# Altium schematic sheet [5]-BeagleBlack Connectors.SchDoc
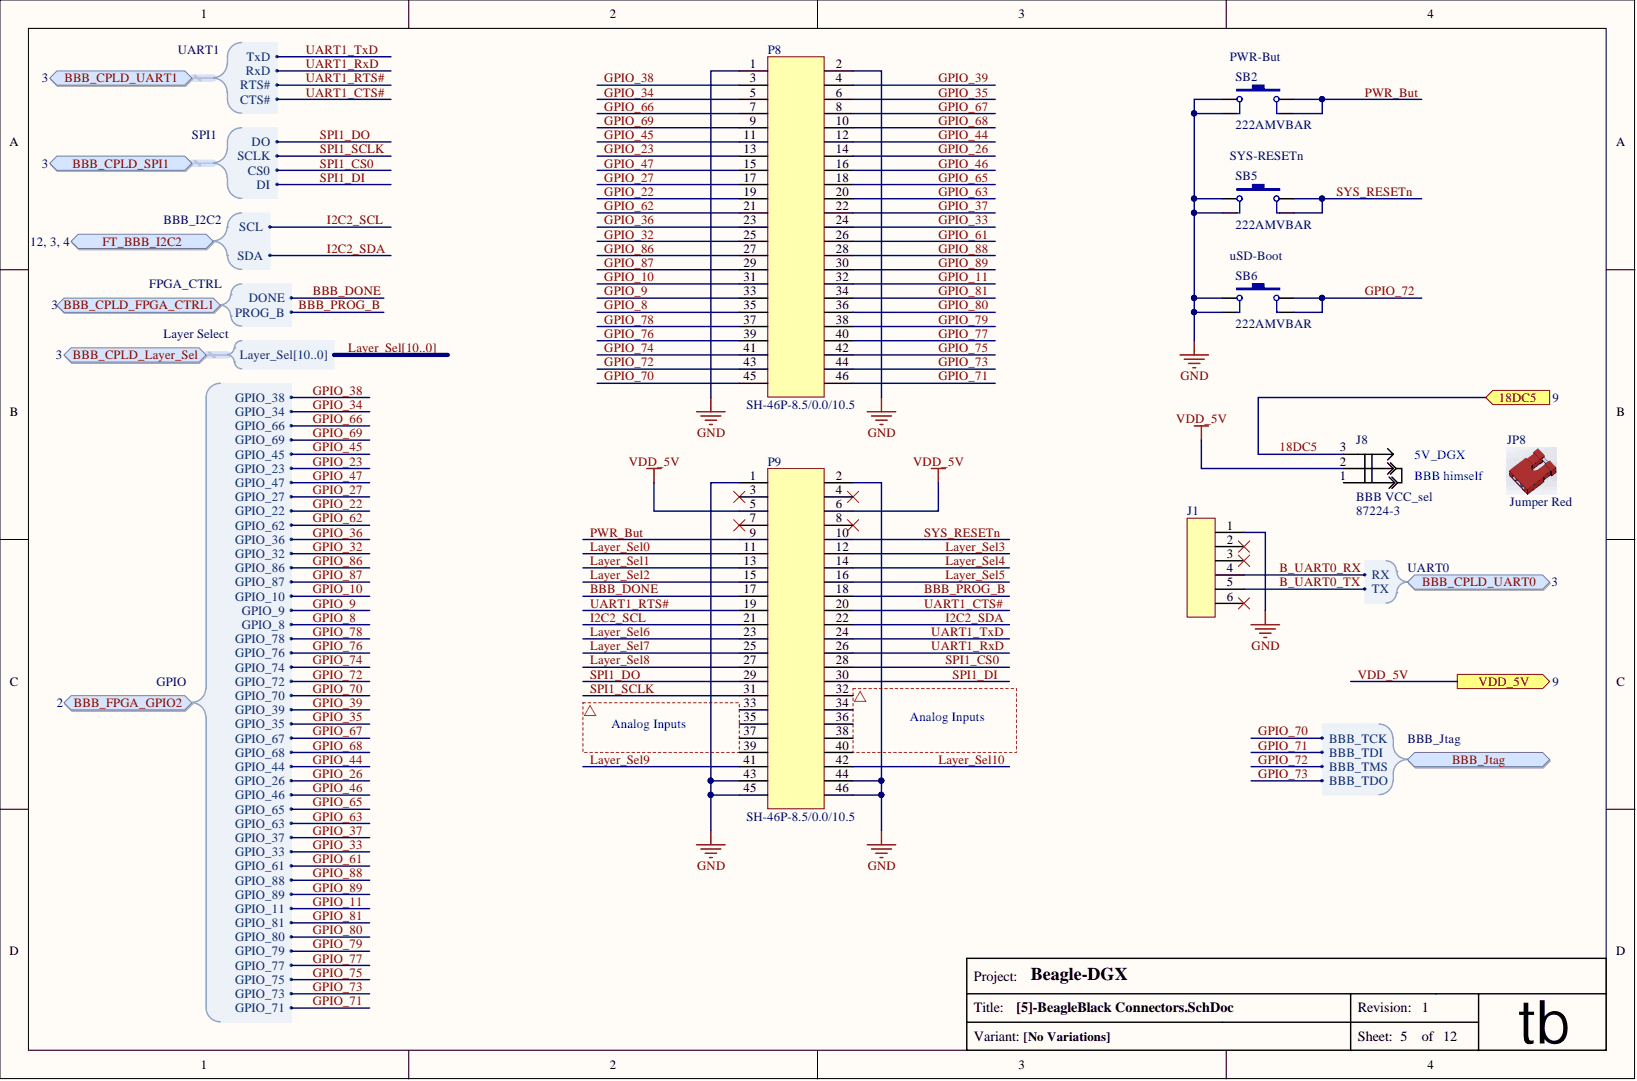
[diagram p0: [5]-BeagleBlack Connectors.SchDoc]

## Parts
J1  conn_Arduino_6p  [Conn_Arduino_6p_2.54]  (value not drawn)
J8  BBB VCC_sel  value 87224-3  Manufacturer Part: 87224-3  [Jumper_3pin_red]
JP8  value Jumper Red  Supplier Part: Jumper  (hidden comment: VCC_sel)  (mechanical)
P8  value SH-46P-8.5/0.0/10.5  Manufacturer Part: SH-46P-8.5/0.0/10.5  [Conn_Beagle_2x23p_2.54]  (hidden comment: conn_Beagle_2x23p)
P9  value SH-46P-8.5/0.0/10.5  Manufacturer Part: SH-46P-8.5/0.0/10.5  [Conn_Beagle_2x23p_2.54]  (hidden comment: conn_Beagle_2x23p)
SB2  PWR-But  value 222AMVBAR  Manufacturer Part: 222AMVBAR  [Key 6.2x6.2]
SB5  SYS-RESETn  value 222AMVBAR  Manufacturer Part: 222AMVBAR  [Key 6.2x6.2]
SB6  uSD-Boot  value 222AMVBAR  Manufacturer Part: 222AMVBAR  [Key 6.2x6.2]

## Ports
18DC5, BBB_CPLD_FPGA_CTRL1, BBB_CPLD_FPGA_CTRL1.DONE, BBB_CPLD_FPGA_CTRL1.PROG_B, BBB_CPLD_Layer_Sel, BBB_CPLD_LAYER_SEL.LAYER_SEL0, BBB_CPLD_LAYER_SEL.LAYER_SEL1, BBB_CPLD_LAYER_SEL.LAYER_SEL2, BBB_CPLD_LAYER_SEL.LAYER_SEL3, BBB_CPLD_LAYER_SEL.LAYER_SEL4, BBB_CPLD_LAYER_SEL.LAYER_SEL5, BBB_CPLD_LAYER_SEL.LAYER_SEL6, BBB_CPLD_LAYER_SEL.LAYER_SEL7, BBB_CPLD_LAYER_SEL.LAYER_SEL8, BBB_CPLD_LAYER_SEL.LAYER_SEL9, BBB_CPLD_LAYER_SEL.LAYER_SEL10, BBB_CPLD_Layer_Sel.Layer_Sel[10..0], BBB_CPLD_SPI1, BBB_CPLD_SPI1.CS0, BBB_CPLD_SPI1.DI, BBB_CPLD_SPI1.DO, BBB_CPLD_SPI1.SCLK, BBB_CPLD_UART0, BBB_CPLD_UART0.RX, BBB_CPLD_UART0.TX, BBB_CPLD_UART1, BBB_CPLD_UART1.CTS#, BBB_CPLD_UART1.RTS#, BBB_CPLD_UART1.RxD, BBB_CPLD_UART1.TxD, BBB_FPGA_GPIO2, BBB_FPGA_GPIO2.GPIO_8, BBB_FPGA_GPIO2.GPIO_9, BBB_FPGA_GPIO2.GPIO_10, BBB_FPGA_GPIO2.GPIO_11, BBB_FPGA_GPIO2.GPIO_22, BBB_FPGA_GPIO2.GPIO_23, BBB_FPGA_GPIO2.GPIO_26, BBB_FPGA_GPIO2.GPIO_27, BBB_FPGA_GPIO2.GPIO_32, BBB_FPGA_GPIO2.GPIO_33, BBB_FPGA_GPIO2.GPIO_34, BBB_FPGA_GPIO2.GPIO_35, BBB_FPGA_GPIO2.GPIO_36, BBB_FPGA_GPIO2.GPIO_37, BBB_FPGA_GPIO2.GPIO_38, BBB_FPGA_GPIO2.GPIO_39, BBB_FPGA_GPIO2.GPIO_44, BBB_FPGA_GPIO2.GPIO_45, BBB_FPGA_GPIO2.GPIO_46, BBB_FPGA_GPIO2.GPIO_47, BBB_FPGA_GPIO2.GPIO_61, BBB_FPGA_GPIO2.GPIO_62, BBB_FPGA_GPIO2.GPIO_63, BBB_FPGA_GPIO2.GPIO_65, BBB_FPGA_GPIO2.GPIO_66, BBB_FPGA_GPIO2.GPIO_67, BBB_FPGA_GPIO2.GPIO_68, BBB_FPGA_GPIO2.GPIO_69, BBB_FPGA_GPIO2.GPIO_70, BBB_FPGA_GPIO2.GPIO_71, BBB_FPGA_GPIO2.GPIO_72, BBB_FPGA_GPIO2.GPIO_73, BBB_FPGA_GPIO2.GPIO_74, BBB_FPGA_GPIO2.GPIO_75, BBB_FPGA_GPIO2.GPIO_76, BBB_FPGA_GPIO2.GPIO_77, BBB_FPGA_GPIO2.GPIO_78, BBB_FPGA_GPIO2.GPIO_79, BBB_FPGA_GPIO2.GPIO_80, BBB_FPGA_GPIO2.GPIO_81, BBB_FPGA_GPIO2.GPIO_86, BBB_FPGA_GPIO2.GPIO_87, BBB_FPGA_GPIO2.GPIO_88, BBB_FPGA_GPIO2.GPIO_89, BBB_Jtag, BBB_Jtag.BBB_TCK, BBB_Jtag.BBB_TDI, BBB_Jtag.BBB_TDO, BBB_Jtag.BBB_TMS, FT_BBB_I2C2, FT_BBB_I2C2.SCL, FT_BBB_I2C2.SDA, VDD_5V

## Nets
GND: J1.1 P8.1 P8.2 P9.1 P9.2 P9.43 P9.44 P9.45 P9.46 SB2.1 SB2.3 SB5.1 SB5.3 SB6.1 SB6.3
18DC5: J8.3 ; port 18DC5
B_UART0_RX: J1.4 ; port BBB_CPLD_UART0
B_UART0_TX: J1.5 ; port BBB_CPLD_UART0
BBB_DONE: P9.17 ; port BBB_CPLD_FPGA_CTRL1
BBB_PROG_B: P9.18 ; port BBB_CPLD_FPGA_CTRL1
GPIO_8: P8.35 ; port BBB_FPGA_GPIO2
GPIO_9: P8.33 ; port BBB_FPGA_GPIO2
GPIO_10: P8.31 ; port BBB_FPGA_GPIO2
GPIO_11: P8.32 ; port BBB_FPGA_GPIO2
GPIO_22: P8.19 ; port BBB_FPGA_GPIO2
GPIO_23: P8.13 ; port BBB_FPGA_GPIO2
GPIO_26: P8.14 ; port BBB_FPGA_GPIO2
GPIO_27: P8.17 ; port BBB_FPGA_GPIO2
GPIO_32: P8.25 ; port BBB_FPGA_GPIO2
GPIO_33: P8.24 ; port BBB_FPGA_GPIO2
GPIO_34: P8.5 ; port BBB_FPGA_GPIO2
GPIO_35: P8.6 ; port BBB_FPGA_GPIO2
GPIO_36: P8.23 ; port BBB_FPGA_GPIO2
GPIO_37: P8.22 ; port BBB_FPGA_GPIO2
GPIO_38: P8.3 ; port BBB_FPGA_GPIO2
GPIO_39: P8.4 ; port BBB_FPGA_GPIO2
GPIO_44: P8.12 ; port BBB_FPGA_GPIO2
GPIO_45: P8.11 ; port BBB_FPGA_GPIO2
GPIO_46: P8.16 ; port BBB_FPGA_GPIO2
GPIO_47: P8.15 ; port BBB_FPGA_GPIO2
GPIO_61: P8.26 ; port BBB_FPGA_GPIO2
GPIO_62: P8.21 ; port BBB_FPGA_GPIO2
GPIO_63: P8.20 ; port BBB_FPGA_GPIO2
GPIO_65: P8.18 ; port BBB_FPGA_GPIO2
GPIO_66: P8.7 ; port BBB_FPGA_GPIO2
GPIO_67: P8.8 ; port BBB_FPGA_GPIO2
GPIO_68: P8.10 ; port BBB_FPGA_GPIO2
GPIO_69: P8.9 ; port BBB_FPGA_GPIO2
GPIO_70: P8.45 ; port BBB_FPGA_GPIO2, port BBB_Jtag
GPIO_71: P8.46 ; port BBB_FPGA_GPIO2, port BBB_Jtag
GPIO_72: P8.43 SB6.2 SB6.4 ; port BBB_FPGA_GPIO2, port BBB_Jtag
GPIO_73: P8.44 ; port BBB_FPGA_GPIO2, port BBB_Jtag
GPIO_74: P8.41 ; port BBB_FPGA_GPIO2
GPIO_75: P8.42 ; port BBB_FPGA_GPIO2
GPIO_76: P8.39 ; port BBB_FPGA_GPIO2
GPIO_77: P8.40 ; port BBB_FPGA_GPIO2
GPIO_78: P8.37 ; port BBB_FPGA_GPIO2
GPIO_79: P8.38 ; port BBB_FPGA_GPIO2
GPIO_80: P8.36 ; port BBB_FPGA_GPIO2
GPIO_81: P8.34 ; port BBB_FPGA_GPIO2
GPIO_86: P8.27 ; port BBB_FPGA_GPIO2
GPIO_87: P8.29 ; port BBB_FPGA_GPIO2
GPIO_88: P8.28 ; port BBB_FPGA_GPIO2
GPIO_89: P8.30 ; port BBB_FPGA_GPIO2
I2C2_SCL: P9.21 ; port FT_BBB_I2C2
I2C2_SDA: P9.22 ; port FT_BBB_I2C2
Layer_Sel0: P9.11 ; port BBB_CPLD_Layer_Sel  [also labelled LAYER_SEL]
Layer_Sel1: P9.13 ; port BBB_CPLD_Layer_Sel  [also labelled LAYER_SEL]
Layer_Sel2: P9.15 ; port BBB_CPLD_Layer_Sel  [also labelled LAYER_SEL]
Layer_Sel3: P9.12 ; port BBB_CPLD_Layer_Sel  [also labelled LAYER_SEL]
Layer_Sel4: P9.14 ; port BBB_CPLD_Layer_Sel  [also labelled LAYER_SEL]
Layer_Sel5: P9.16 ; port BBB_CPLD_Layer_Sel  [also labelled LAYER_SEL]
Layer_Sel6: P9.23 ; port BBB_CPLD_Layer_Sel  [also labelled LAYER_SEL]
Layer_Sel7: P9.25 ; port BBB_CPLD_Layer_Sel  [also labelled LAYER_SEL]
Layer_Sel8: P9.27 ; port BBB_CPLD_Layer_Sel  [also labelled LAYER_SEL]
Layer_Sel9: P9.41 ; port BBB_CPLD_Layer_Sel  [also labelled LAYER_SEL]
Layer_Sel10: P9.42 ; port BBB_CPLD_Layer_Sel  [also labelled LAYER_SEL]
PWR_But: P9.9 SB2.2 SB2.4
SPI1_CS0: P9.28 ; port BBB_CPLD_SPI1
SPI1_DI: P9.30 ; port BBB_CPLD_SPI1
SPI1_DO: P9.29 ; port BBB_CPLD_SPI1
SPI1_SCLK: P9.31 ; port BBB_CPLD_SPI1
SYS_RESETn: P9.10 SB5.2 SB5.4
UART1_CTS#: P9.20 ; port BBB_CPLD_UART1
UART1_RTS#: P9.19 ; port BBB_CPLD_UART1
UART1_RxD: P9.26 ; port BBB_CPLD_UART1
UART1_TxD: P9.24 ; port BBB_CPLD_UART1
VDD_5V: J8.2 P9.5 P9.6 ; port VDD_5V

Not connected: J1 2 3 6; J8 1; P9 3 4 7 8 32 33 34 35 36 37 38 39 40

## Library data of the parts
J1 = conn_Arduino_6p: PLS-6 2.54mm Pitch, 10.5mm High; Manufacturer Part: Header 1x6; Type of Component: Header; Component Kind: Standard; Package / Case: Header 1x6; Supplier: aliexpress.com; Supplier Link: http://aliexpress.com/item/40PCS-x-8-Pin-40x-6-Pin-Stackable-Female-Shield-Header-Tall-Stacking-Kit-Special-long/689203895.html; Library Name: RPI-VITA.IntLib
J8 = jumper_3pin: Manufacturer: TE Connectivity / AMP; Type of Component: Jumper; Component Kind: Standard; Manufacturer Link: http://www.te.com/usa-en/product-87224-3.html#pdp-related-products-inner; Package / Case: Header 1 x 3; Supplier: mouser; Supplier Link: http://www.mouser.ee/ProductDetail/TE-Connectivity-AMP/87224-3/?qs=sGAEpiMZZMs%252bGHln7q6pm48SVpWlpfsErguO%2fp0873w%3d; Supplier Part: 571-872243; Library Name: RPI-VITA.IntLib
JP8 = jumper red: Manufacturer: Great Electric; Manufacturer Part: Jumper Cap Shunts Short Circuit Cap; Package / Case: 2.54mm; Supplier: aliexpress.com; Supplier Link: http://www.aliexpress.com/item/500pcs-lot-Asssorted-Color-2-54mm-Standard-Circuit-Board-Jumper-Cap-Shunts-Short-Circuit-Cap-CGKCH119/32344305619.html?spm=2114.03010208.3.80.nPPmoy&ws_ab_test=searchweb201556_1,searchweb201644_1_505_506_503_504_502_10001_10002_10017_10010_10005_10011_10006_10003_10004_10009_10007_10008,searchweb201560_5,searchweb1451318400_-1,searchweb1451318411_6452&btsid=16d11777-edde-4755-b8b5-9adacb495b09; Type of Component: Jumper; Library Name: RPI-VITA.IntLib
P8 P9 = conn_Beagle_2x23p: Arduino 2x23 2.54mm Pitch; Manufacturer: Hellotronics; Type of Component: Header 2x23; Component Kind: Standard; Package / Case: Header 2x23; Supplier: aliexpress.com; Supplier Link: http://aliexpress.com/item/50-pcs-lot-Stacking-Header-Set-for-Beagle-Bone-Capes-2x23-Stackable-Header-0-1-Pitch/32406383172.html; Supplier Part: SH-46P-8.5/0.0/10.5; Library Name: RPI-VITA.IntLib
SB2 SB5 SB6 = SB_Tactile: Tactile Switches; Manufacturer: E-Switch; Type of Component: Tact Switch; Component Kind: Standard; Package / Case: 6.2 x 6.2 x 5; Supplier: mouser; Supplier Link: http://www.mouser.ee/ProductDetail/CTS-Electronic-Components/222AMVBAR/?qs=sGAEpiMZZMsgGjVA3toVBB5GWLGpesfgV6sVMBFzGDw%3d; Supplier Part: 774-222AMVBAR; Library Name: RPI-VITA.IntLib
